# Altium schematic sheet 419-005-01-02_PG 09 - DDR.SchDoc("419-005-01-02_PG 09 - DDR")
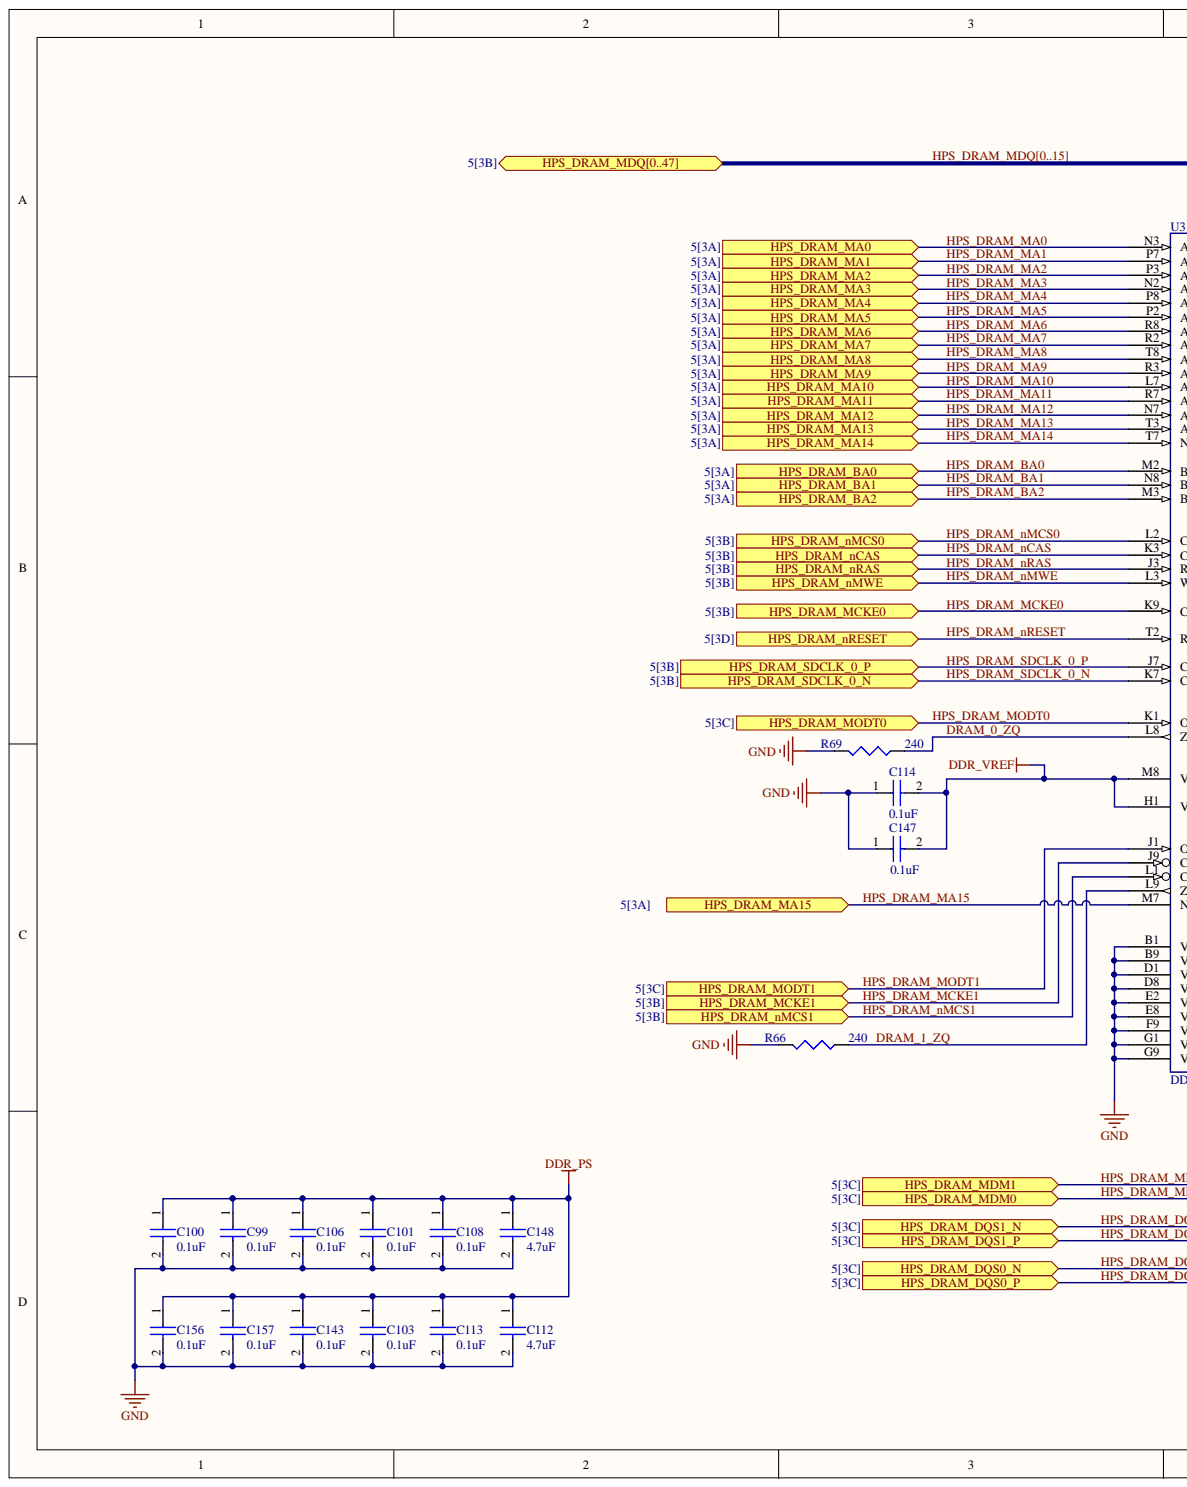
[diagram p0: 419-005-01-02_PG 09 - DDR.SchDoc, left]
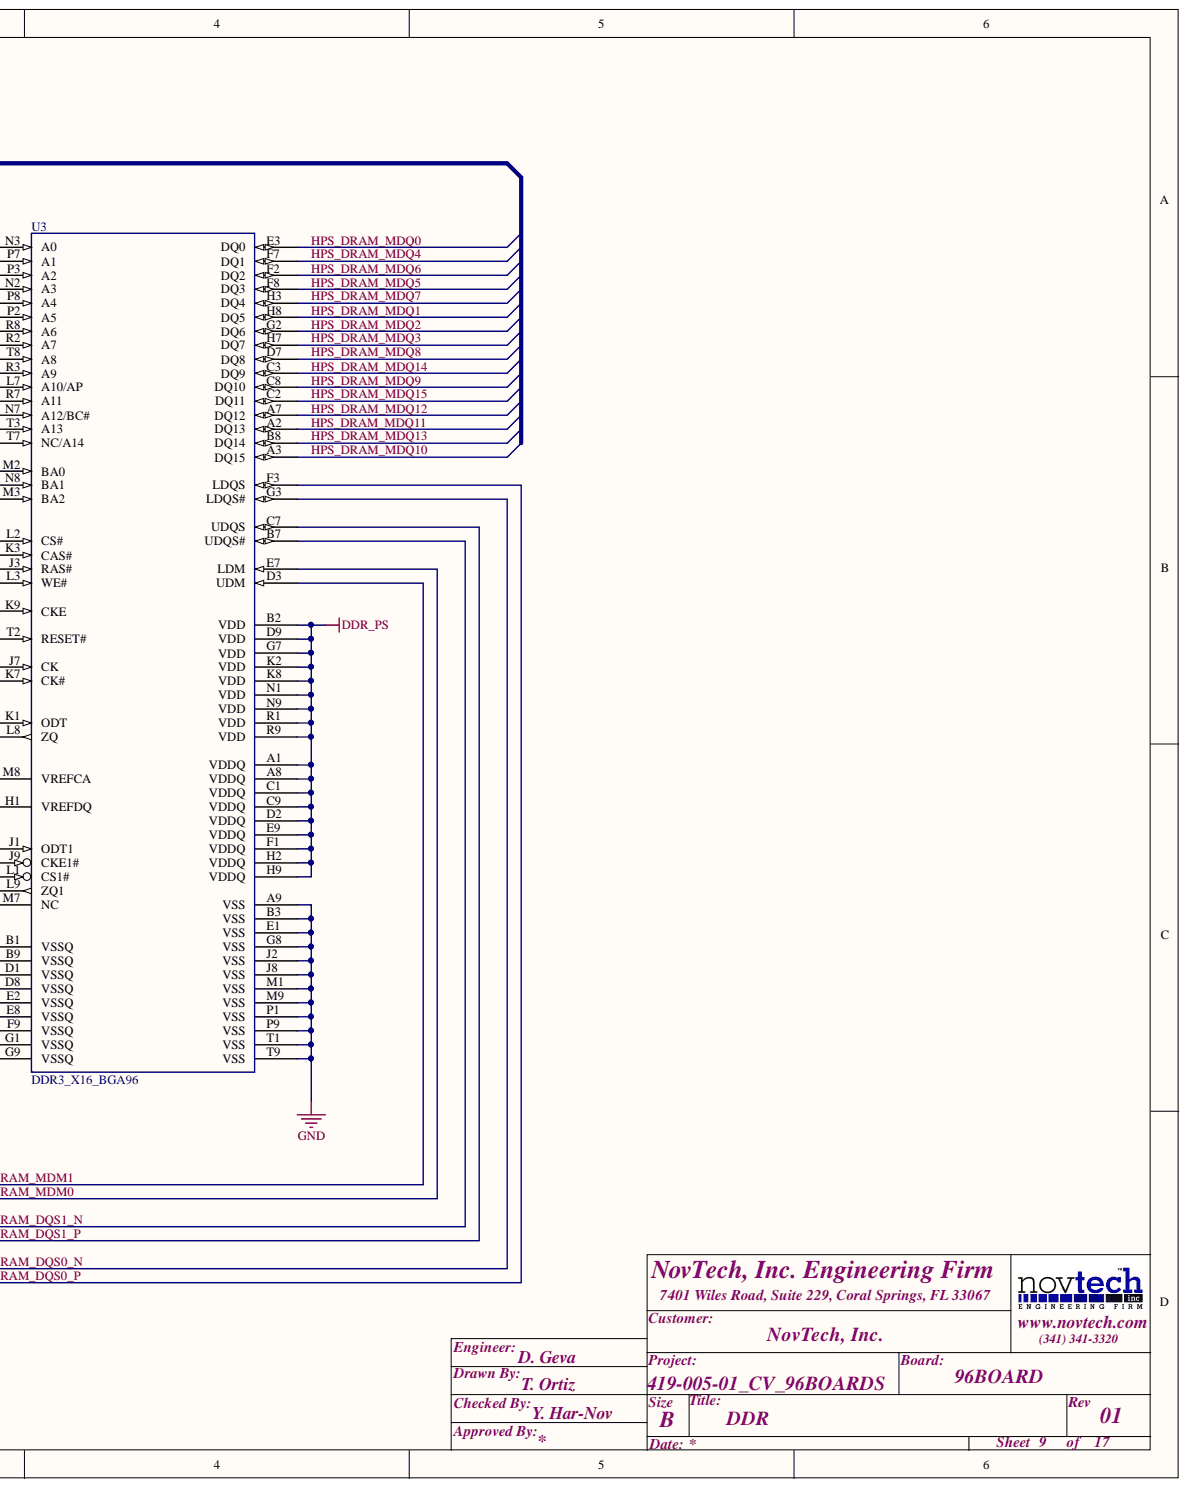
[diagram p1: 419-005-01-02_PG 09 - DDR.SchDoc, right]

## Parts
C99  0.1uF  [0201_C]
C100  0.1uF  [0201_C]
C101  0.1uF  [0201_C]
C103  0.1uF  [0201_C]
C106  0.1uF  [0201_C]
C108  0.1uF  [0201_C]
C112  4.7uF  [0402_C]
C113  0.1uF  [0201_C]
C114  0.1uF  [0201_C]
C143  0.1uF  [0201_C]
C147  0.1uF  [0201_C]
C148  4.7uF  [0402_C]
C156  0.1uF  [0201_C]
C157  0.1uF  [0201_C]
R66  240  [0201_R]
R69  240  [0201_R]
U3  DDR3_X16_BGA96  [BGA96C80P16X9_1400X900X120]

## Ports
HPS_DRAM_BA0, HPS_DRAM_BA1, HPS_DRAM_BA2, HPS_DRAM_DQS0_N, HPS_DRAM_DQS0_P, HPS_DRAM_DQS1_N, HPS_DRAM_DQS1_P, HPS_DRAM_MA0, HPS_DRAM_MA1, HPS_DRAM_MA2, HPS_DRAM_MA3, HPS_DRAM_MA4, HPS_DRAM_MA5, HPS_DRAM_MA6, HPS_DRAM_MA7, HPS_DRAM_MA8, HPS_DRAM_MA9, HPS_DRAM_MA10, HPS_DRAM_MA11, HPS_DRAM_MA12, HPS_DRAM_MA13, HPS_DRAM_MA14, HPS_DRAM_MA15, HPS_DRAM_MCKE0, HPS_DRAM_MCKE1, HPS_DRAM_MDM0, HPS_DRAM_MDM1, HPS_DRAM_MDQ0, HPS_DRAM_MDQ1, HPS_DRAM_MDQ2, HPS_DRAM_MDQ3, HPS_DRAM_MDQ4, HPS_DRAM_MDQ5, HPS_DRAM_MDQ6, HPS_DRAM_MDQ7, HPS_DRAM_MDQ8, HPS_DRAM_MDQ9, HPS_DRAM_MDQ10, HPS_DRAM_MDQ11, HPS_DRAM_MDQ12, HPS_DRAM_MDQ13, HPS_DRAM_MDQ14, HPS_DRAM_MDQ15, HPS_DRAM_MDQ16, HPS_DRAM_MDQ17, HPS_DRAM_MDQ18, HPS_DRAM_MDQ19, HPS_DRAM_MDQ20, HPS_DRAM_MDQ21, HPS_DRAM_MDQ22, HPS_DRAM_MDQ23, HPS_DRAM_MDQ24, HPS_DRAM_MDQ25, HPS_DRAM_MDQ26, HPS_DRAM_MDQ27, HPS_DRAM_MDQ28, HPS_DRAM_MDQ29, HPS_DRAM_MDQ30, HPS_DRAM_MDQ31, HPS_DRAM_MDQ32, HPS_DRAM_MDQ33, HPS_DRAM_MDQ34, HPS_DRAM_MDQ35, HPS_DRAM_MDQ36, HPS_DRAM_MDQ37, HPS_DRAM_MDQ38, HPS_DRAM_MDQ39, HPS_DRAM_MDQ40, HPS_DRAM_MDQ41, HPS_DRAM_MDQ42, HPS_DRAM_MDQ43, HPS_DRAM_MDQ44, HPS_DRAM_MDQ45, HPS_DRAM_MDQ46, HPS_DRAM_MDQ47, HPS_DRAM_MDQ[0..47], HPS_DRAM_MODT0, HPS_DRAM_MODT1, HPS_DRAM_NCAS, HPS_DRAM_NMCS0, HPS_DRAM_NMCS1, HPS_DRAM_NMWE, HPS_DRAM_NRAS, HPS_DRAM_NRESET, HPS_DRAM_SDCLK_0_N, HPS_DRAM_SDCLK_0_P

## Nets
DDR_PS: C99.1 C100.1 C101.1 C103.1 C106.1 C108.1 C112.1 C113.1 C143.1 C148.1 C156.1 C157.1 U3.A1(VDDQ) U3.A8(VDDQ) U3.B2(VDD) U3.C1(VDDQ) U3.C9(VDDQ) U3.D2(VDDQ) U3.D9(VDD) U3.E9(VDDQ) U3.F1(VDDQ) U3.G7(VDD) U3.H2(VDDQ) U3.H9(VDDQ) U3.K2(VDD) U3.K8(VDD) U3.N1(VDD) U3.N9(VDD) U3.R1(VDD) U3.R9(VDD)
DDR_VREF: C114.2 C147.2 U3.H1(VREFDQ) U3.M8(VREFCA)
GND: C99.2 C100.2 C101.2 C103.2 C106.2 C108.2 C112.2 C113.2 C114.1 C143.2 C147.1 C148.2 C156.2 C157.2 R66.2 R69.2 U3.A9(VSS) U3.B1(VSSQ) U3.B3(VSS) U3.B9(VSSQ) U3.D1(VSSQ) U3.D8(VSSQ) U3.E1(VSS) U3.E2(VSSQ) U3.E8(VSSQ) U3.F9(VSSQ) U3.G1(VSSQ) U3.G8(VSS) U3.G9(VSSQ) U3.J2(VSS) U3.J8(VSS) U3.M1(VSS) U3.M9(VSS) U3.P1(VSS) U3.P9(VSS) U3.T1(VSS) U3.T9(VSS)
DRAM_0_ZQ: R69.1 U3.L8(ZQ)
DRAM_1_ZQ: R66.1 U3.L9(ZQ1)
HPS_DRAM_BA0: U3.M2(BA0) ; port HPS_DRAM_BA0
HPS_DRAM_BA1: U3.N8(BA1) ; port HPS_DRAM_BA1
HPS_DRAM_BA2: U3.M3(BA2) ; port HPS_DRAM_BA2
HPS_DRAM_DQS0_N: U3.G3(LDQS#) ; port HPS_DRAM_DQS0_N
HPS_DRAM_DQS0_P: U3.F3(LDQS) ; port HPS_DRAM_DQS0_P
HPS_DRAM_DQS1_N: U3.B7(UDQS#) ; port HPS_DRAM_DQS1_N
HPS_DRAM_DQS1_P: U3.C7(UDQS) ; port HPS_DRAM_DQS1_P
HPS_DRAM_MA0: U3.N3(A0) ; port HPS_DRAM_MA0
HPS_DRAM_MA1: U3.P7(A1) ; port HPS_DRAM_MA1
HPS_DRAM_MA2: U3.P3(A2) ; port HPS_DRAM_MA2
HPS_DRAM_MA3: U3.N2(A3) ; port HPS_DRAM_MA3
HPS_DRAM_MA4: U3.P8(A4) ; port HPS_DRAM_MA4
HPS_DRAM_MA5: U3.P2(A5) ; port HPS_DRAM_MA5
HPS_DRAM_MA6: U3.R8(A6) ; port HPS_DRAM_MA6
HPS_DRAM_MA7: U3.R2(A7) ; port HPS_DRAM_MA7
HPS_DRAM_MA8: U3.T8(A8) ; port HPS_DRAM_MA8
HPS_DRAM_MA9: U3.R3(A9) ; port HPS_DRAM_MA9
HPS_DRAM_MA10: U3.L7(A10/AP) ; port HPS_DRAM_MA10
HPS_DRAM_MA11: U3.R7(A11) ; port HPS_DRAM_MA11
HPS_DRAM_MA12: U3.N7(A12/BC#) ; port HPS_DRAM_MA12
HPS_DRAM_MA13: U3.T3(A13) ; port HPS_DRAM_MA13
HPS_DRAM_MA14: U3.T7(NC/A14) ; port HPS_DRAM_MA14
HPS_DRAM_MA15: U3.M7(NC) ; port HPS_DRAM_MA15
HPS_DRAM_MCKE0: U3.K9(CKE) ; port HPS_DRAM_MCKE0
HPS_DRAM_MCKE1: U3.J9(CKE1#) ; port HPS_DRAM_MCKE1
HPS_DRAM_MDM0: U3.E7(LDM) ; port HPS_DRAM_MDM0
HPS_DRAM_MDM1: U3.D3(UDM) ; port HPS_DRAM_MDM1
HPS_DRAM_MDQ0: U3.E3(DQ0) ; port HPS_DRAM_MDQ[0..47]  [also labelled HPS_DRAM_MDQ]
HPS_DRAM_MDQ1: U3.H8(DQ5) ; port HPS_DRAM_MDQ[0..47]  [also labelled HPS_DRAM_MDQ]
HPS_DRAM_MDQ2: U3.G2(DQ6) ; port HPS_DRAM_MDQ[0..47]  [also labelled HPS_DRAM_MDQ]
HPS_DRAM_MDQ3: U3.H7(DQ7) ; port HPS_DRAM_MDQ[0..47]  [also labelled HPS_DRAM_MDQ]
HPS_DRAM_MDQ4: U3.F7(DQ1) ; port HPS_DRAM_MDQ[0..47]  [also labelled HPS_DRAM_MDQ]
HPS_DRAM_MDQ5: U3.F8(DQ3) ; port HPS_DRAM_MDQ[0..47]  [also labelled HPS_DRAM_MDQ]
HPS_DRAM_MDQ6: U3.F2(DQ2) ; port HPS_DRAM_MDQ[0..47]  [also labelled HPS_DRAM_MDQ]
HPS_DRAM_MDQ7: U3.H3(DQ4) ; port HPS_DRAM_MDQ[0..47]  [also labelled HPS_DRAM_MDQ]
HPS_DRAM_MDQ8: U3.D7(DQ8) ; port HPS_DRAM_MDQ[0..47]  [also labelled HPS_DRAM_MDQ]
HPS_DRAM_MDQ9: U3.C8(DQ10) ; port HPS_DRAM_MDQ[0..47]  [also labelled HPS_DRAM_MDQ]
HPS_DRAM_MDQ10: U3.A3(DQ15) ; port HPS_DRAM_MDQ[0..47]  [also labelled HPS_DRAM_MDQ]
HPS_DRAM_MDQ11: U3.A2(DQ13) ; port HPS_DRAM_MDQ[0..47]  [also labelled HPS_DRAM_MDQ]
HPS_DRAM_MDQ12: U3.A7(DQ12) ; port HPS_DRAM_MDQ[0..47]  [also labelled HPS_DRAM_MDQ]
HPS_DRAM_MDQ13: U3.B8(DQ14) ; port HPS_DRAM_MDQ[0..47]  [also labelled HPS_DRAM_MDQ]
HPS_DRAM_MDQ14: U3.C3(DQ9) ; port HPS_DRAM_MDQ[0..47]  [also labelled HPS_DRAM_MDQ]
HPS_DRAM_MDQ15: U3.C2(DQ11) ; port HPS_DRAM_MDQ[0..47]  [also labelled HPS_DRAM_MDQ]
HPS_DRAM_MODT0: U3.K1(ODT) ; port HPS_DRAM_MODT0
HPS_DRAM_MODT1: U3.J1(ODT1) ; port HPS_DRAM_MODT1
HPS_DRAM_NCAS: U3.K3(CAS#) ; port HPS_DRAM_NCAS
HPS_DRAM_NMCS0: U3.L2(CS#) ; port HPS_DRAM_NMCS0
HPS_DRAM_NMCS1: U3.L1(CS1#) ; port HPS_DRAM_NMCS1
HPS_DRAM_NMWE: U3.L3(WE#) ; port HPS_DRAM_NMWE
HPS_DRAM_NRAS: U3.J3(RAS#) ; port HPS_DRAM_NRAS
HPS_DRAM_NRESET: U3.T2(RESET#) ; port HPS_DRAM_NRESET
HPS_DRAM_SDCLK_0_N: U3.K7(CK#) ; port HPS_DRAM_SDCLK_0_N
HPS_DRAM_SDCLK_0_P: U3.J7(CK) ; port HPS_DRAM_SDCLK_0_P

## Library data of the parts
C99-C101 C103 C106 C108 C113 C114 C143 C147 C156 C157 = CAP_0201: Capasitor 0201 footprint; Component Kind: Standard; Library Name: PASSIVES.SchLib
C112 C148 = CAP_0402: Capasitor 0402 footprint; Bottom: 10; Right: 20; Component Kind: Standard; Library Name: PASSIVES.SchLib
R66 R69 = RESISOTR 0201: Resistor 0201 footprint; PART: ERJ-1GEF2400C; MFG: Panasonic; Component Kind: Standard; Digikey: P240ABTR-ND; Library Name: PASSIVES.SchLib; RoHS: Yes; TYPE: SMT
U3 = DDR3_X16_BGA96: Component Kind: Standard; Library Name: IC_SchLib.SCHLIB
